# Altium schematic sheet HomeLight.SchDoc
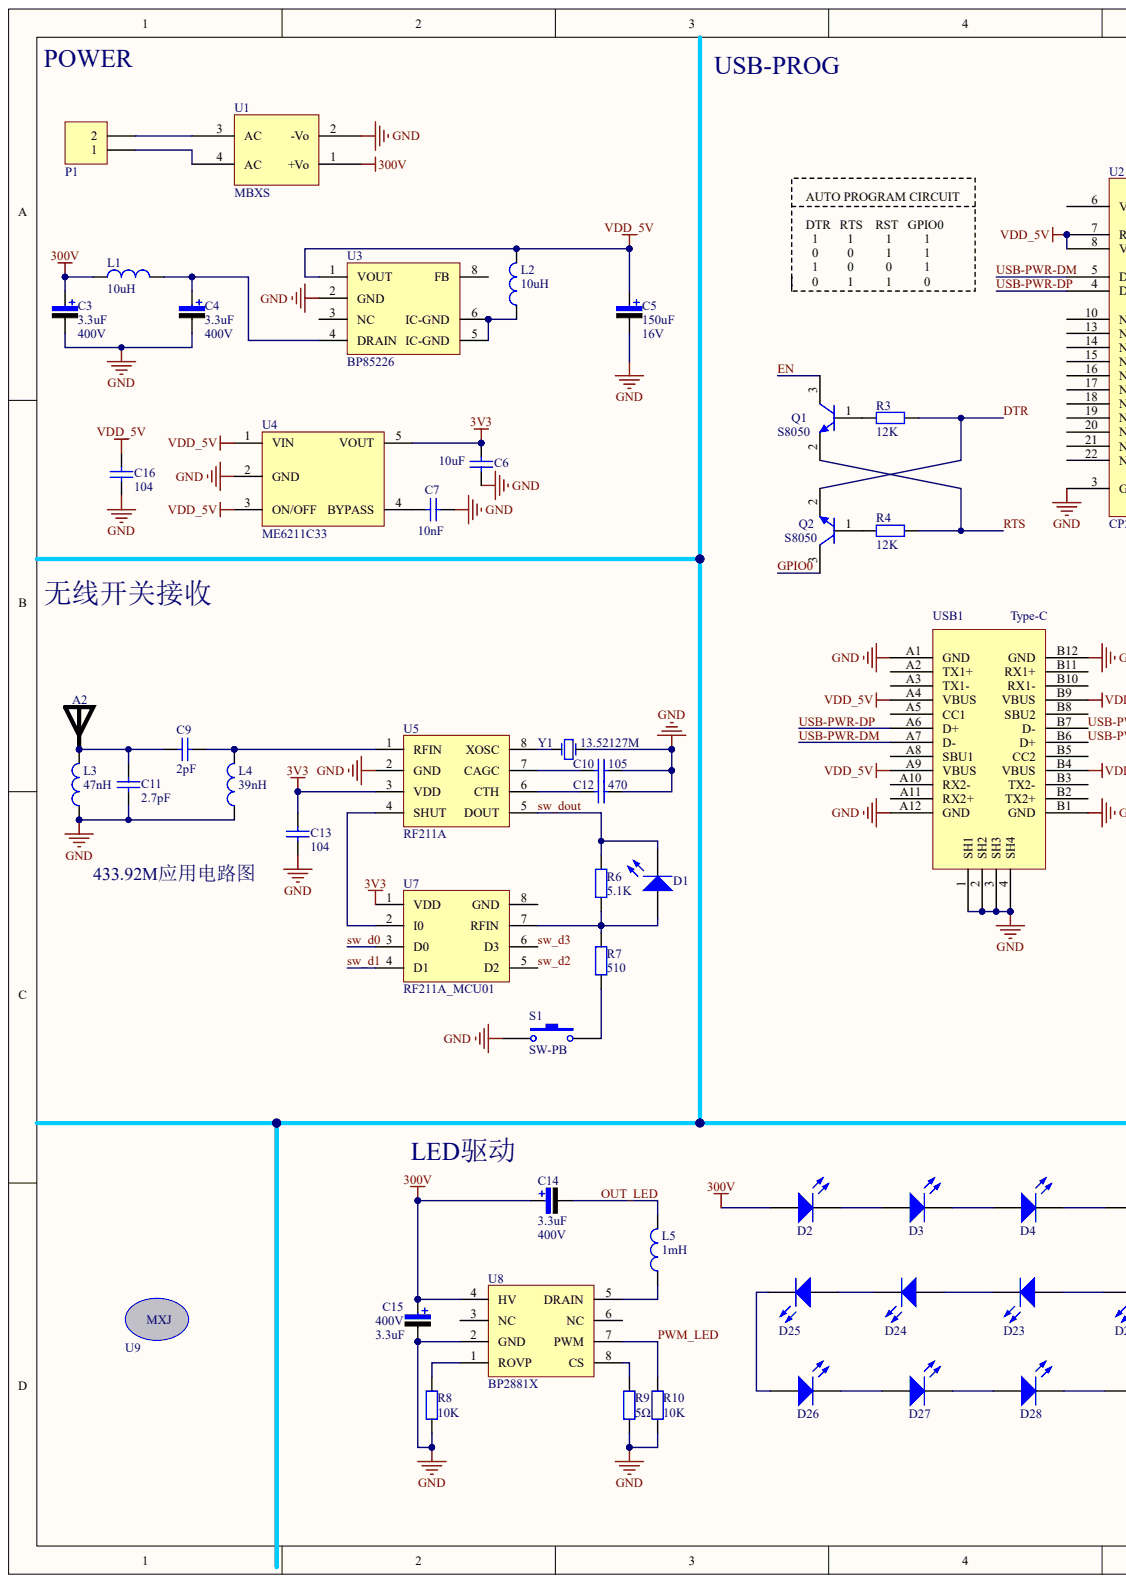
[diagram p0: HomeLight.SchDoc, left]
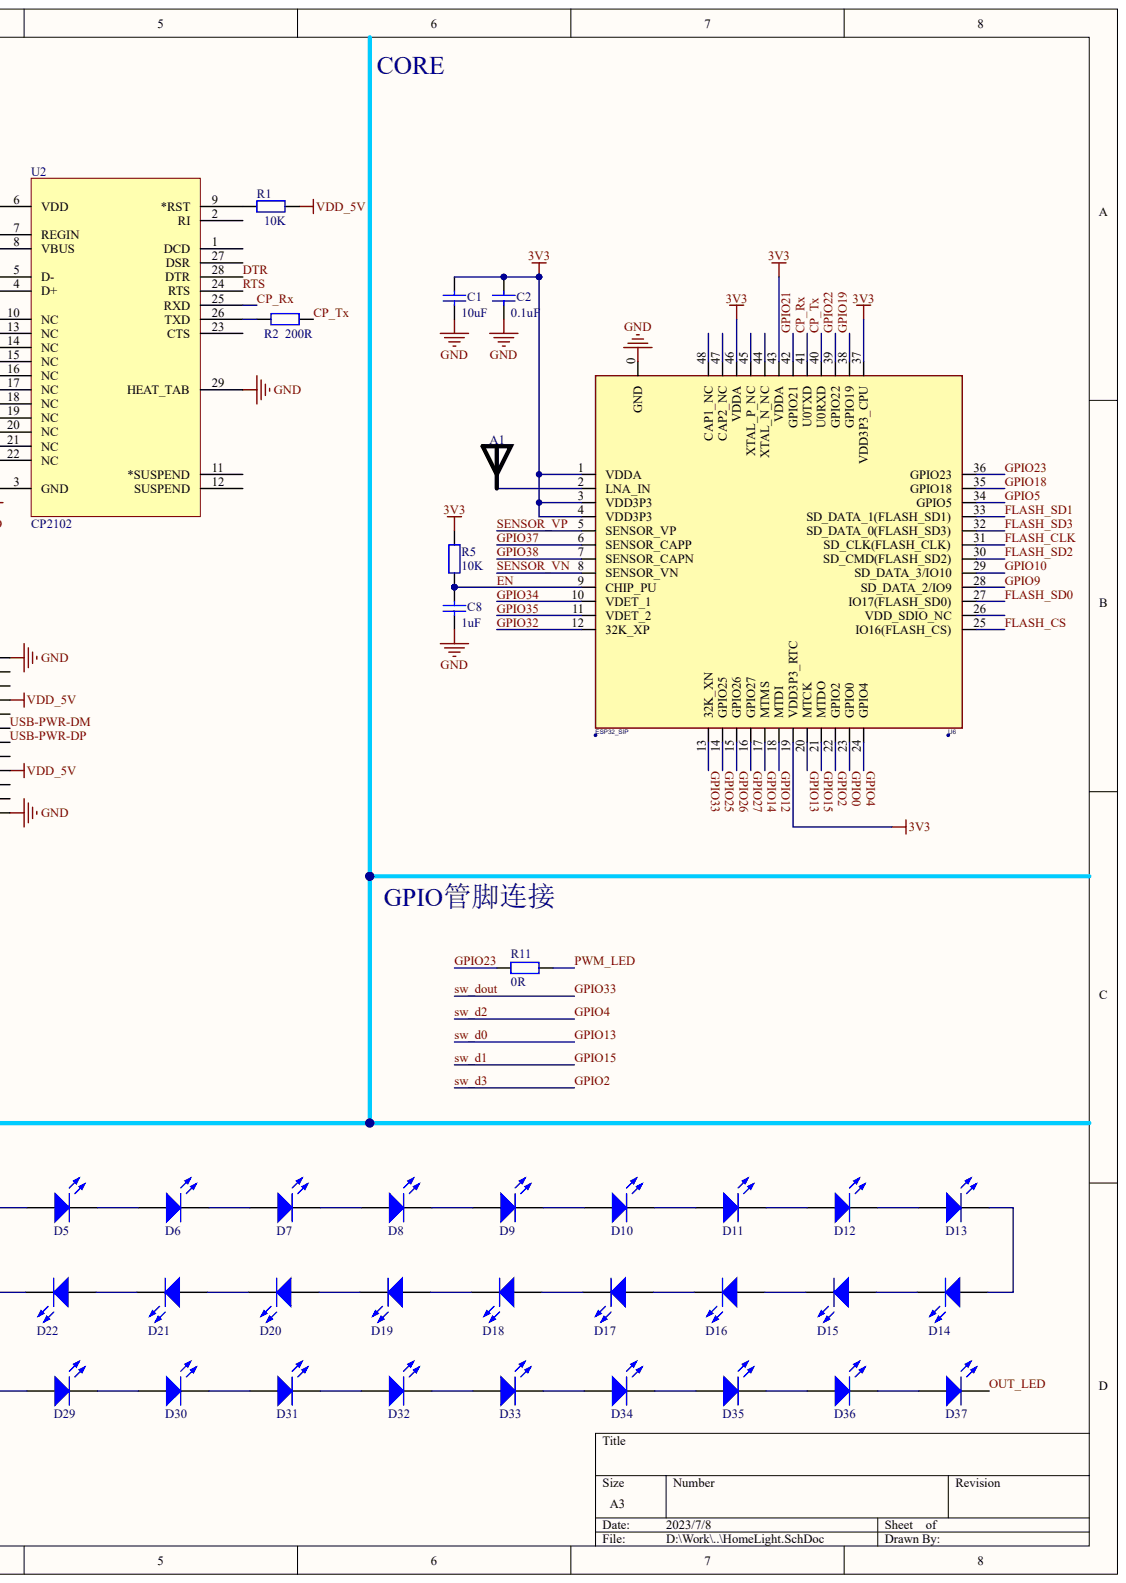
[diagram p1: HomeLight.SchDoc, right]

## Parts
A1  ANT  (value not drawn)
A2  ANT  (value not drawn)
C1  10uF
C2  0.1uF
C3  value 3.3uF  Vol: 400V  (hidden comment: Cap Pol)
C4  value 3.3uF  Vol: 400V  (hidden comment: Cap Pol)
C5  value 150uF  Vol: 16V  (hidden comment: Cap Pol)
C6  10uF
C7  10nF
C8  1uF
C9  value 2pF  (hidden comment: Cap)
C10  value 105  (hidden comment: Cap)
C11  value 2.7pF  (hidden comment: Cap)
C12  value 470  (hidden comment: Cap)
C13  value 104  (hidden comment: Cap)
C14  value 3.3uF  Vol: 400V  (hidden comment: Cap Pol)
C15  value 3.3uF  Vol: 400V  (hidden comment: Cap Pol)
C16  value 104  (hidden comment: Cap)
D1  LED0  (value not drawn)
D2  LED0  (value not drawn)
D3  LED0  (value not drawn)
D4  LED0  (value not drawn)
D5  LED0  (value not drawn)
D6  LED0  (value not drawn)
D7  LED0  (value not drawn)
D8  LED0  (value not drawn)
D9  LED0  (value not drawn)
D10  LED0  (value not drawn)
D11  LED0  (value not drawn)
D12  LED0  (value not drawn)
D13  LED0  (value not drawn)
D14  LED0  (value not drawn)
D15  LED0  (value not drawn)
D16  LED0  (value not drawn)
D17  LED0  (value not drawn)
D18  LED0  (value not drawn)
D19  LED0  (value not drawn)
D20  LED0  (value not drawn)
D21  LED0  (value not drawn)
D22  LED0  (value not drawn)
D23  LED0  (value not drawn)
D24  LED0  (value not drawn)
D25  LED0  (value not drawn)
D26  LED0  (value not drawn)
D27  LED0  (value not drawn)
D28  LED0  (value not drawn)
D29  LED0  (value not drawn)
D30  LED0  (value not drawn)
D31  LED0  (value not drawn)
D32  LED0  (value not drawn)
D33  LED0  (value not drawn)
D34  LED0  (value not drawn)
D35  LED0  (value not drawn)
D36  LED0  (value not drawn)
D37  LED0  (value not drawn)
L1  value 10uH  (hidden comment: Inductor)
L2  value 10uH  (hidden comment: Inductor)
L3  value 47nH  (hidden comment: Inductor)
L4  value 39nH  (hidden comment: Inductor)
L5  value 1mH  (hidden comment: Inductor)
P1  Header 2  (value not drawn)
Q1  S8050
Q2  S8050
R1  10K
R2  200R
R3  12K
R4  12K
R5  10K
R6  value 5.1K  (hidden comment: Res1)
R7  value 510  (hidden comment: Res1)
R8  value 10K  (hidden comment: Res1)
R9  value 5Ω  (hidden comment: Res1)
R10  value 10K  (hidden comment: Res1)
R11  value 0R  (hidden comment: Res1)
S1  SW-PB
U1  MBXS
U2  CP2102
U3  BP85226
U4  ME6211C33
U5  RF211A
U6  ESP32_SIP
U7  RF211A_MCU01
U8  BP2881X
U9  logo_MXJ  (value not drawn)
USB1  Type-C
Y1  value 13.52127M  (hidden comment: XTAL)

## Nets
3V3: C1.2 C2.2 C6.2 C13.1 R5.1 U4.5(VOUT) U5.3(VDD) U6.1(VDDA) U6.3(VDD3P3) U6.4(VDD3P3) U6.19(VDD3P3_RTC) U6.37(VDD3P3_CPU) U6.43(VDDA) U6.46(VDDA) U7.1(VDD)
300V: C3.1 C14.1 C15.1 D2.1 L1.1 U1.1(+Vo) U8.4(HV)
GND: C1.1 C2.1 C3.2 C4.2 C5.2 C6.1 C7.1 C8.2 C10.1 C11.2 C12.1 C13.2 C15.2 C16.2 L3.1 L4.1 R8.1 R9.1 R10.1 S1.1 U1.2(-Vo) U2.3(GND) U2.29(HEAT_TAB) U3.2(GND) U4.2(GND) U5.2(GND) U6.0(GND) U8.2(GND) USB1.1(SH1) USB1.2(SH2) USB1.3(SH3) USB1.4(SH4) USB1.A1(GND) USB1.A12(GND) USB1.B1(GND) USB1.B12(GND) Y1.2
VDD_5V: C5.1 C16.1 L2.2 R1.1 U2.7(REGIN) U2.8(VBUS) U3.1(VOUT) U4.1(VIN) U4.3(ON/OFF) USB1.A4(VBUS) USB1.A9(VBUS) USB1.B4(VBUS) USB1.B9(VBUS)
CP_RX: U2.25(RXD) U6.41(U0TXD)
CP_TX: R2.1 U6.40(U0RXD)
DTR: Q2.2 R3.1 U2.28(DTR)
EN: C8.1 Q1.3 R5.2 U6.9(CHIP_PU)
FLASH_CLK: U6.31(SD_CLK(FLASH_CLK))
FLASH_CS: U6.25(IO16(FLASH_CS))
FLASH_SD0: U6.27(IO17(FLASH_SD0))
FLASH_SD1: U6.33(SD_DATA_1(FLASH_SD1))
FLASH_SD2: U6.30(SD_CMD(FLASH_SD2))
FLASH_SD3: U6.32(SD_DATA_0(FLASH_SD3))
GPIO0: Q2.3 U6.23(GPIO0)
GPIO2: U6.22(GPIO2) U7.6(D3)
GPIO4: U6.24(GPIO4) U7.5(D2)
GPIO5: U6.34(GPIO5)
GPIO9: U6.28(SD_DATA_2/IO9)
GPIO10: U6.29(SD_DATA_3/IO10)
GPIO12: U6.18(MTDI)
GPIO13: U6.20(MTCK) U7.3(D0)
GPIO14: U6.17(MTMS)
GPIO15: U6.21(MTDO) U7.4(D1)
GPIO18: U6.35(GPIO18)
GPIO19: U6.38(GPIO19)
GPIO21: U6.42(GPIO21)
GPIO22: U6.39(GPIO22)
GPIO23: R11.2 U6.36(GPIO23)
GPIO25: U6.14(GPIO25)
GPIO26: U6.15(GPIO26)
GPIO27: U6.16(GPIO27)
GPIO32: U6.12(32K_XP)
GPIO33: D1.2 R6.2 U5.5(DOUT) U6.13(32K_XN)
GPIO34: U6.10(VDET_1)
GPIO35: U6.11(VDET_2)
GPIO37: U6.6(SENSOR_CAPP)
GPIO38: U6.7(SENSOR_CAPN)
OUT_LED: C14.2 D37.2 L5.2
PWM_LED: R10.2 R11.1 U8.7(PWM)
RTS: Q1.2 R4.1 U2.24(RTS)
SENSOR_VN: U6.8(SENSOR_VN)
SENSOR_VP: U6.5(SENSOR_VP)
USB-PWR-DM: U2.5(D-) USB1.A7(D-) USB1.B7(D-)
USB-PWR-DP: U2.4(D+) USB1.A6(D+) USB1.B6(D+)
NetA1_1: A1.1 U6.2(LNA_IN)
NetA2_1: A2.1 C9.1 C11.1 L3.2
NetC4_1: C4.1 L1.2 U3.4(DRAIN)
NetC7_2: C7.2 U4.4(BYPASS)
NetC9_2: C9.2 L4.2 U5.1(RFIN)
NetC10_2: C10.2 U5.7(CAGC)
NetC12_2: C12.2 U5.6(CTH)
NetD1_1: D1.1 R6.1 R7.2 U7.7(RFIN)
NetD2_2: D2.2 D3.1
NetD3_2: D3.2 D4.1
NetD4_2: D4.2 D5.1
NetD5_2: D5.2 D6.1
NetD6_2: D6.2 D7.1
NetD7_2: D7.2 D8.1
NetD8_2: D8.2 D9.1
NetD9_2: D9.2 D10.1
NetD10_2: D10.2 D11.1
NetD11_2: D11.2 D12.1
NetD12_2: D12.2 D13.1
NetD13_2: D13.2 D14.1
NetD14_2: D14.2 D15.1
NetD15_2: D15.2 D16.1
NetD16_2: D16.2 D17.1
NetD17_2: D17.2 D18.1
NetD18_2: D18.2 D19.1
NetD19_2: D19.2 D20.1
NetD20_2: D20.2 D21.1
NetD21_2: D21.2 D22.1
NetD22_2: D22.2 D23.1
NetD23_2: D23.2 D24.1
NetD24_2: D24.2 D25.1
NetD25_2: D25.2 D26.1
NetD26_2: D26.2 D27.1
NetD27_2: D27.2 D28.1
NetD28_2: D28.2 D29.1
NetD29_2: D29.2 D30.1
NetD30_2: D30.2 D31.1
NetD31_2: D31.2 D32.1
NetD32_2: D32.2 D33.1
NetD33_2: D33.2 D34.1
NetD34_2: D34.2 D35.1
NetD35_2: D35.2 D36.1
NetD36_2: D36.2 D37.1
NetL2_1: L2.1 U3.5(IC-GND) U3.6(IC-GND)
NetL5_1: L5.1 U8.5(DRAIN)
NetP1_1: P1.1 U1.4(AC)
NetP1_2: P1.2 U1.3(AC)
NetQ1_1: Q1.1 R3.2
NetQ2_1: Q2.1 R4.2
NetR1_2: R1.2 U2.9(*RST)
NetR2_2: R2.2 U2.26(TXD)
NetR7_1: R7.1 S1.2
NetR8_2: R8.2 U8.1(ROVP)
NetR9_2: R9.2 U8.8(CS)
NetU5_4: U5.4(SHUT) U7.2(I0)
NetU5_8: U5.8(XOSC) Y1.1
N00038:

Not connected: U2 1(DCD) 2(RI) 6(VDD) 10(NC) 11(*SUSPEND) 12(SUSPEND) 13(NC) 14(NC) 15(NC) 16(NC) 17(NC) 18(NC) 19(NC) 20(NC) 21(NC) 22(NC) 23(CTS) 27(DSR); U3 3(NC) 8(FB); U6 26(VDD_SDIO_NC) 44(XTAL_N_NC) 45(XTAL_P_NC) 47(CAP2_NC) 48(CAP1_NC); U7 8(GND); U8 3(NC) 6(NC); USB1 A2(TX1+) A3(TX1-) A5(CC1) A8(SBU1) A10(RX2-) A11(RX2+) B2(TX2+) B3(TX2-) B5(CC2) B8(SBU2) B10(RX1-) B11(RX1+)

## Library data of the parts
L1-L5 = (no symbol name): Code_IEC: 1005; Published: 8-Jun-2000; LatestRevisionDate: 17-Jul-2002; LatestRevisionNote: Re-released for DXP Platform.; PackageReference: 0402-A; Code_JEITA: 0402; Publisher: Altium Limited
U2 = (no symbol name): PART DESC: SINGLE-CHIP USB TO UART BRIDGE, QFN28, RoHS.; MFG #1: SILICON LABS; PART NUMBER: CP2102; Value: Value; RefDes: RefDes; Type: DEV
A1 A2 C1-C16 D1-D37 P1 Q1 Q2 R1-R11 S1 U1 U3-U9 USB1 Y1 = (no symbol name)
  C1 C6: Value: 10uF; Published: 8-Jun-2000; LatestRevisionDate: 17-Jul-2002; LatestRevisionNote: Re-released for DXP Platform.; PackageReference: RAD-0.3
  C2: Value: 0.1uF; Published: 8-Jun-2000; LatestRevisionDate: 17-Jul-2002; LatestRevisionNote: Re-released for DXP Platform.; PackageReference: RAD-0.3
  C3-C5 C14 C15: Published: 8-Jun-2000; LatestRevisionDate: 17-Jul-2002; LatestRevisionNote: Re-released for DXP Platform.; PackageReference: RB7.6-15
  C7: Value: 10nF; Published: 8-Jun-2000; LatestRevisionDate: 17-Jul-2002; LatestRevisionNote: Re-released for DXP Platform.; PackageReference: RAD-0.3
  C8: Value: 1uF; Published: 8-Jun-2000; LatestRevisionDate: 17-Jul-2002; LatestRevisionNote: Re-released for DXP Platform.; PackageReference: RAD-0.3
  C9-C13 C16: Published: 8-Jun-2000; LatestRevisionDate: 17-Jul-2002; LatestRevisionNote: Re-released for DXP Platform.; PackageReference: RAD-0.3
  D1-D37: Published: 8-Jun-2000; LatestRevisionDate: 08-Jul-2005; LatestRevisionNote: Mech Layer 1 removed.; PackageReference: LED-0
  P1: LatestRevisionDate: 17-Jul-2002; LatestRevisionNote: Re-released for DXP Platform.
  Q1 Q2: Published: 8-Jun-2000; LatestRevisionDate: 15-Jan-2003; LatestRevisionNote: PCB Footprint 'BCY-W3/D4.7' replaced; DatasheetDocument: 1997; PackageDocument: Sep-1998; PackageReference: TO-92A; Code_JEDEC: TO-92A; ComponentLink1Description: Manufacturer Link; ComponentLink1URL: http://www.fairchildsemi.com/; ComponentLink2Description: Datasheet; ComponentLink2URL: http://www.fairchildsemi.com/ds/2N%2F2N3904.pdf
  R1 R5: Value: 10K; Published: 8-Jun-2000; LatestRevisionDate: 17-Jul-2002; LatestRevisionNote: Re-released for DXP Platform.; PackageReference: AXIAL-0.4
  R2: Value: 200R; Published: 8-Jun-2000; LatestRevisionDate: 17-Jul-2002; LatestRevisionNote: Re-released for DXP Platform.; PackageReference: AXIAL-0.4
  R3 R4: Value: 12K; Published: 8-Jun-2000; LatestRevisionDate: 17-Jul-2002; LatestRevisionNote: Re-released for DXP Platform.; PackageReference: AXIAL-0.4
  R6-R11: Published: 8-Jun-2000; LatestRevisionDate: 17-Jul-2002; LatestRevisionNote: Re-released for DXP Platform.; PackageReference: AXIAL-0.4
  S1: Published: 24-Mar-1999; LatestRevisionDate: 17-Jul-2002; LatestRevisionNote: Re-released for DXP Platform.; PackageReference: SPST-2
  Y1: Published: 8-Jun-2000; LatestRevisionDate: 17-Jul-2002; LatestRevisionNote: Re-released for DXP Platform.; PackageReference: R38
  C1-C16 D1-D37 P1 Q1 Q2 R1-R11 S1 Y1: Publisher: Altium Limited
